# Altium schematic sheet GSv1_schematic.SchDoc
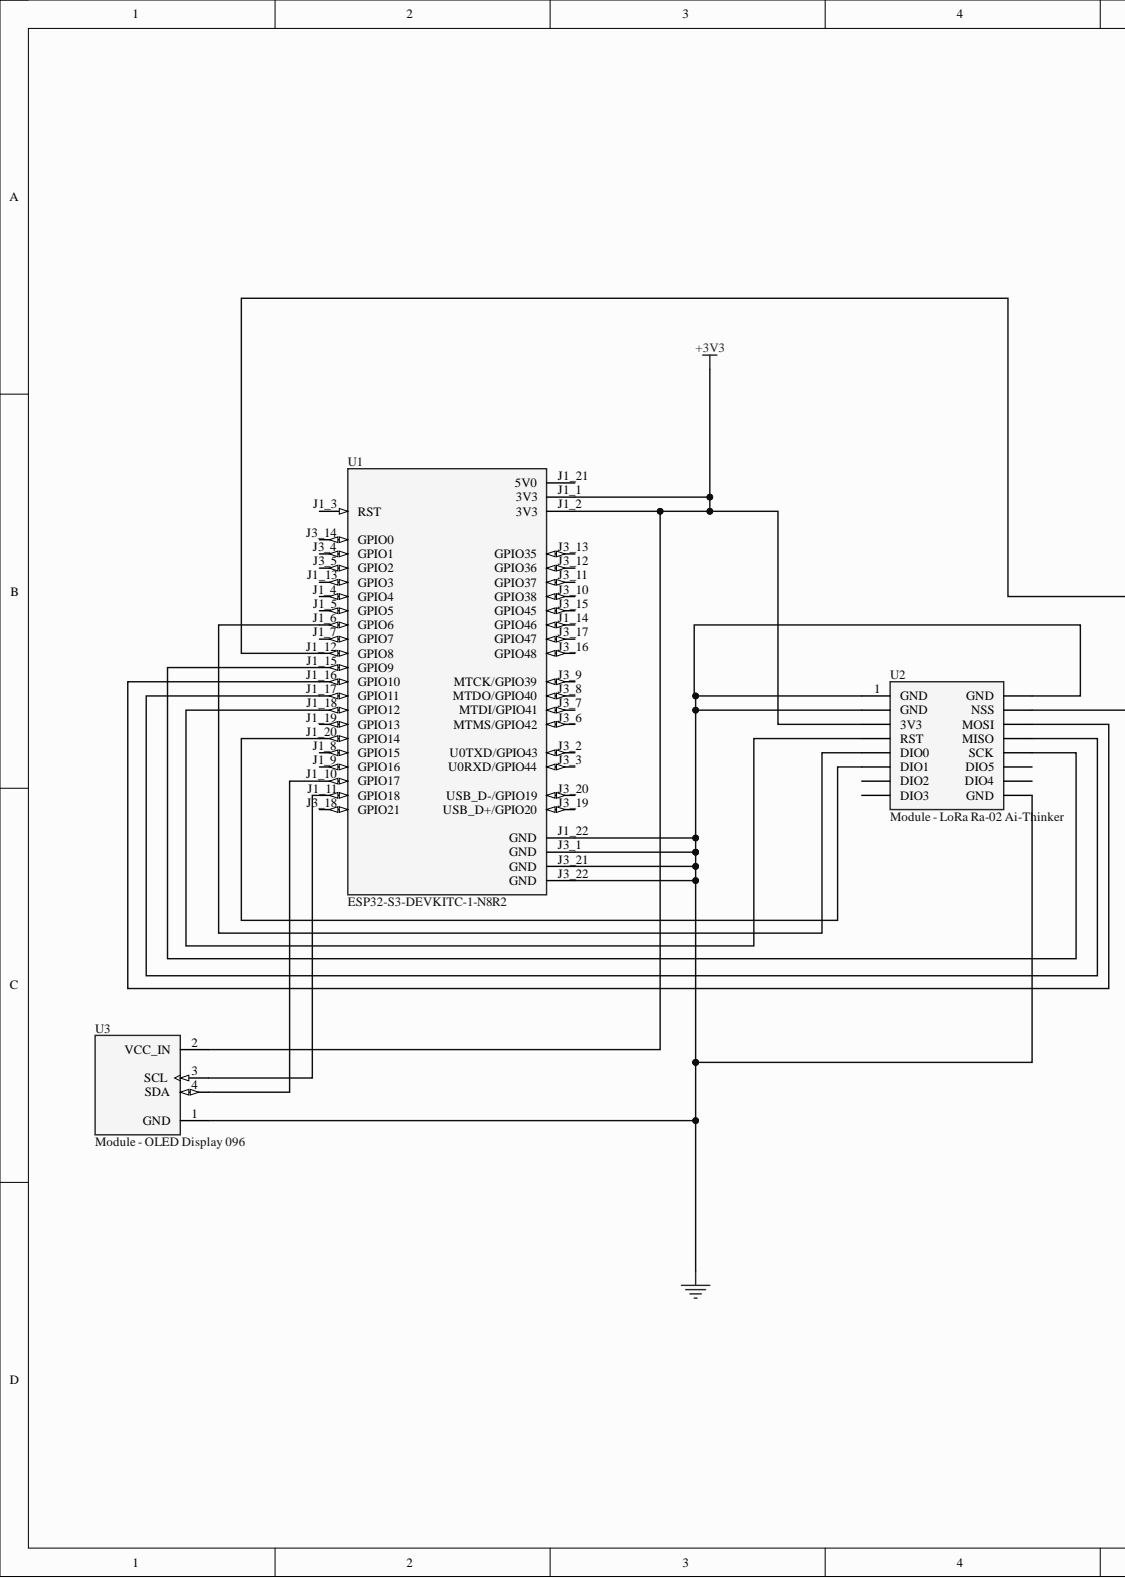
[diagram p0: GSv1_schematic.SchDoc, left]
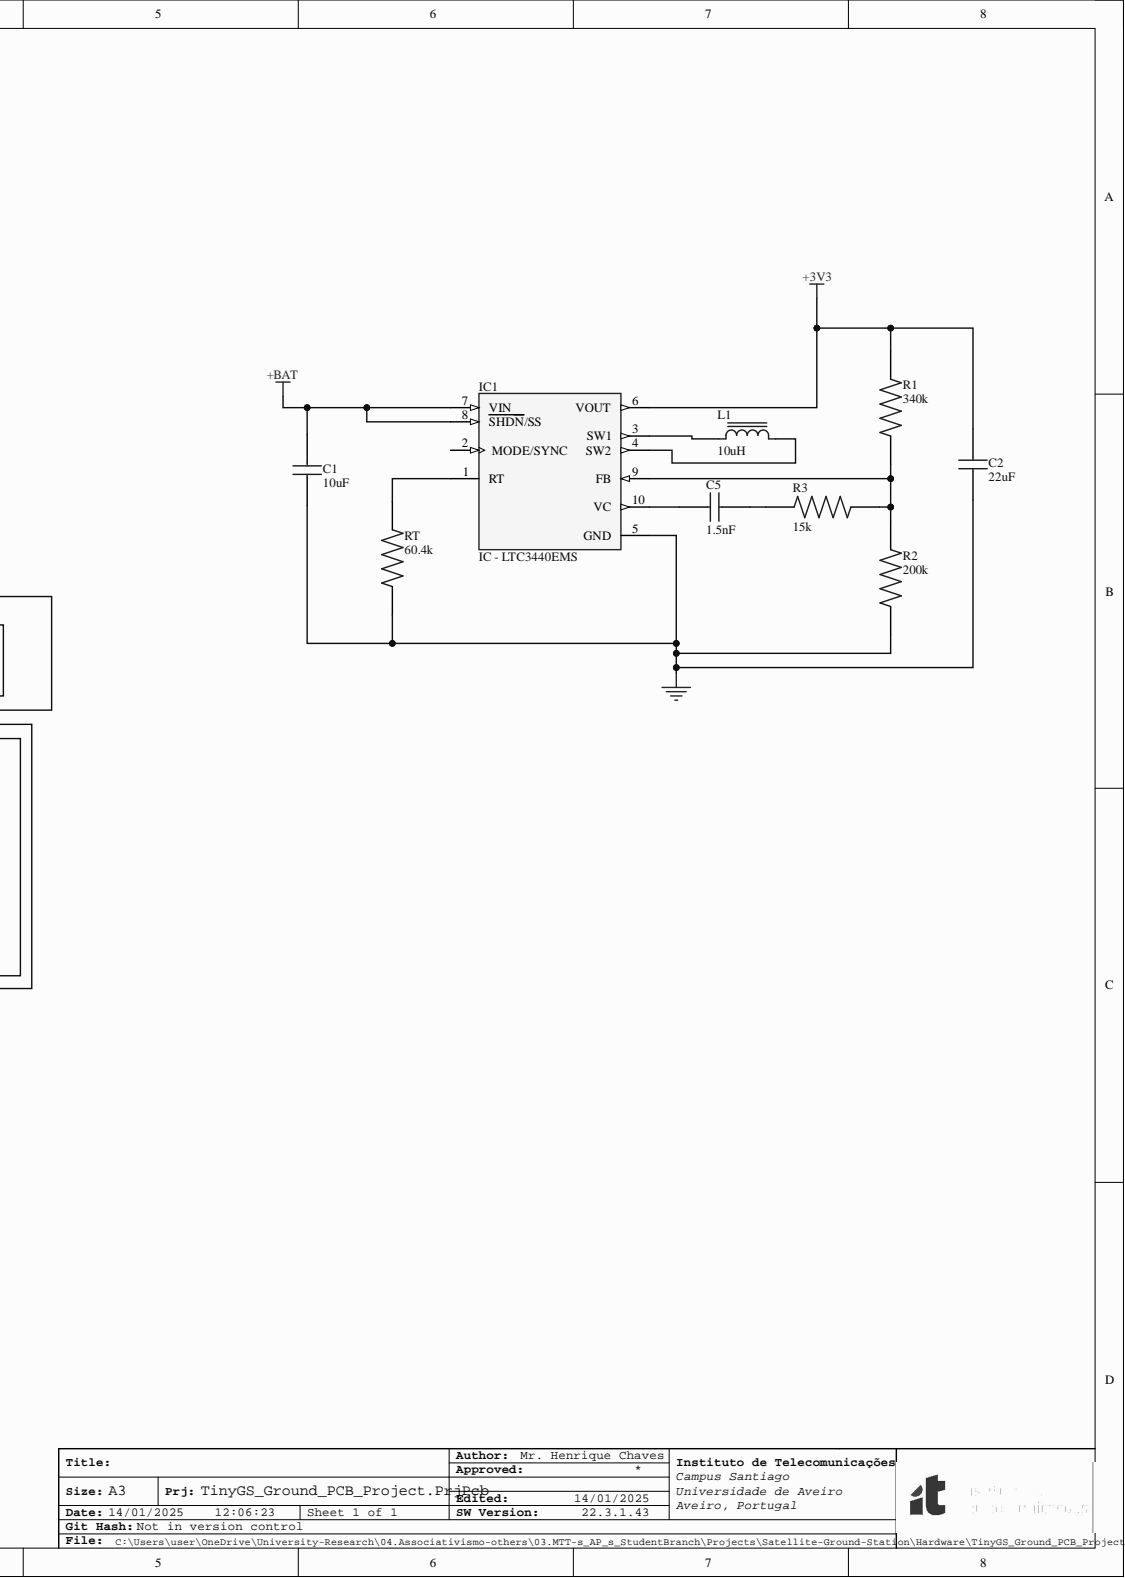
[diagram p1: GSv1_schematic.SchDoc, right]

## Parts
C1  10uF  [0603]
C2  22uF  [0603]
C5  1.5nF  [0603]
IC1  IC - LTC3440EMS  [MSOP-10]
L1  10uH  [CDRH6D]
R1  340k  [0603]
R2  200k  [0603]
R3  15k  [0603]
RT  60.4k  [0603]
U1  ESP32-S3-DEVKITC-1-N8R2  [ORIGINAL_ESP32-S3-DEVKITC_1]
U2  Module - LoRa Ra-02 Ai-Thinker  [MODULE LoRa Ra-02 Ai Thinker_separate rows]
U3  Module - OLED Display 096  [MODULE_DM-OLED096-636]

## Nets
+3V3: C2.2 IC1.6(VOUT) R1.2 U1.J1_1(3V3) U1.J1_2(3V3) U2.3(3V3) U3.2(VCC_IN)
+BAT: C1.2 IC1.7(VIN) IC1.8(SHDN/SS)
GND: C1.1 C2.1 IC1.5(GND) R2.1 RT.1 U1.J1_22(GND) U1.J3_1(GND) U1.J3_21(GND) U1.J3_22(GND) U2.1(GND) U2.2(GND) U2.9(GND) U2.16(GND) U3.1(GND)
NetC5_1: C5.1 R3.1
NetC5_2: C5.2 IC1.10(VC)
NetIC1_1: IC1.1(RT) RT.2
NetIC1_3: IC1.3(SW1) L1.1
NetIC1_4: IC1.4(SW2) L1.2
NetIC1_9: IC1.9(FB) R1.1 R2.2 R3.2
NetU1_J1_6: U1.J1_6(GPIO6) U2.5(DIO0)
NetU1_J1_10: U1.J1_10(GPIO17) U3.4(SDA)
NetU1_J1_11: U1.J1_11(GPIO18) U3.3(SCL)
NetU1_J1_12: U1.J1_12(GPIO8) U2.10(NSS)
NetU1_J1_15: U1.J1_15(GPIO9) U2.13(SCK)
NetU1_J1_16: U1.J1_16(GPIO10) U2.11(MOSI)
NetU1_J1_17: U1.J1_17(GPIO11) U2.12(MISO)
NetU1_J1_18: U1.J1_18(GPIO12) U2.4(RST)
NetU1_J1_20: U1.J1_20(GPIO14) U2.6(DIO1)

Not connected: IC1 2(MODE/SYNC); U1 J1_3(RST) J1_4(GPIO4) J1_5(GPIO5) J1_7(GPIO7) J1_8(GPIO15) J1_9(GPIO16) J1_13(GPIO3) J1_14(GPIO46) J1_19(GPIO13) J1_21(5V0) J3_2(U0TXD/GPIO43) J3_3(U0RXD/GPIO44) J3_4(GPIO1) J3_5(GPIO2) J3_6(MTMS/GPIO42) J3_7(MTDI/GPIO41) J3_8(MTDO/GPIO40) J3_9(MTCK/GPIO39) J3_10(GPIO38) J3_11(GPIO37) J3_12(GPIO36) J3_13(GPIO35) J3_14(GPIO0) J3_15(GPIO45) J3_16(GPIO48) J3_17(GPIO47) J3_18(GPIO21) J3_19(USB_D+/GPIO20) J3_20(USB_D-/GPIO19); U2 7(DIO2) 8(DIO3) 14(DIO5) 15(DIO4)

## Library data of the parts
C1 C2 C5 = CAP - SMD_0603(inch): SMD Ceramic Capacitor; Component Kind: Standard; Library Name: Passive_Devices.SchLib
IC1 = IC - LTC3440EMS: Micropower Synchronous Buck-Boost DC/DC Converter  3V3 regulator to Li-ion Battery; MF: Analog Devices; MP: LTC3440EMS#TRPBF; Availability: In Stock; Check_prices: https://www.snapeda.com/parts/LTC3440EMS%23TRPBF/Analog+Devices/view-part/?ref=eda; Component Kind: Standard; Library Name: Devices_SMD_plus_DIP.SchLib; Package: MSOP-10 Analog Devices; Price: None; Purchase-URL: https://pricing.snapeda.com/search/part/LTC3440EMS#TRPBF/?ref=eda; SnapEDA_Link: https://www.snapeda.com/parts/LTC3440EMS%23TRPBF/Analog+Devices/view-part/?ref=snap
L1 = IND - CDRH6D38 SMD: 10uH Shielded Inductor 2A 38mOhm Max Nonstandard  CDRH6D38NP-100NC SMD; MF: Sumida America; Availability: Unavailable; Check_prices: https://www.digikey.pt/en/products/detail/sumida-america-inc/CDRH6D38NP-100NC/1207151?curr=usd&utm_campaign=buynow&utm_medium=aggregator&utm_source=octopart; Component Kind: Standard; Library Name: Passive_Devices.SchLib; MP: CDRH6D38; Package: Nonstandard Sumida America; Price: None
R1-R3 RT = Resistor - SMD_0603(inch): SMD resistor; Component Kind: Standard; Library Name: Passive_Devices.SchLib
U1 = ESP32-S3-DEVKITC-1-N8R2: WiFi Development Tools - 802.11 (Engineering Samples Only) ESP32-S3 General-Purpose Development Board, ESP32-S3-WROOM-1-N8R2, with Pin Header; MF: Espressif Systems; Availability: In Stock; Check_prices: https://www.snapeda.com/parts/ESP32-S3-DEVKITC-1-N8R2/Espressif+Systems/view-part/?ref=eda; Component Kind: Standard; Library Name: Devices_SMD_plus_DIP.SchLib; MP: ESP32-S3-DEVKITC-1-N8R2; Package: None; Price: None; Purchase-URL: https://pricing.snapeda.com/search/part/ESP32-S3-DEVKITC-1-N8R2/?ref=eda; SnapEDA_Link: https://www.snapeda.com/parts/ESP32-S3-DEVKITC-1-N8R2/Espressif+Systems/view-part/?ref=snap
U2 = Module - LoRa Ra-02 Ai-Thinker: LoRa 433 MHz Module; Component Kind: Standard; Library Name: Devices_SMD_plus_DIP.SchLib
U3 = Module - OLED Display 096: 0.96â€ 128 X 64 MONOCHROME GRAPHIC OLED DISPLAY MODULE - I2C; Component Kind: Standard; Library Name: Devices_SMD_plus_DIP.SchLib
